# Altium schematic sheet IMU.SchDoc
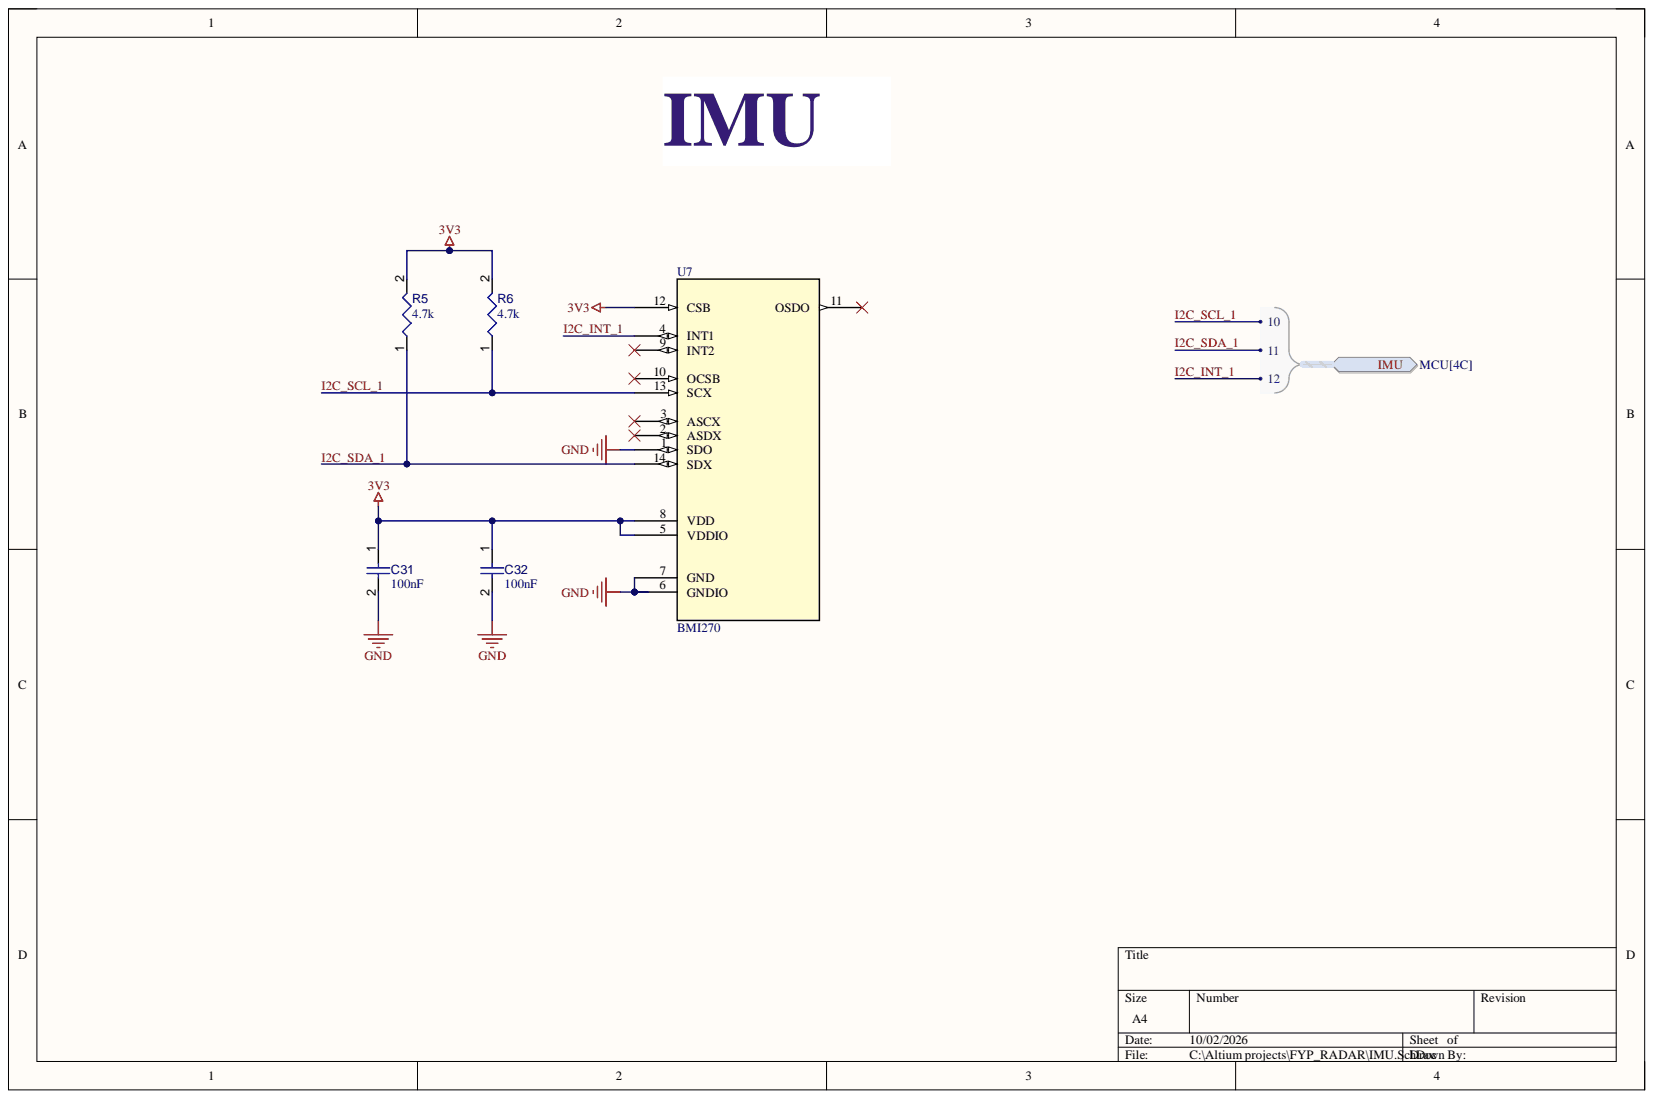
[diagram p0: IMU.SchDoc]

## Parts
C31  value 100nF  [CAPC1608X90X40NL10T20]  (hidden comment: Capacitor 100 nF +/-10% 50 V 0603)
C32  value 100nF  [CAPC1608X90X40NL10T20]  (hidden comment: Capacitor 100 nF +/-10% 50 V 0603)
R5  value 4.7k  [RESC1608X55X25ML10T15]  (hidden comment: Resistor 4k7 +/-1% 0603 100 mW)
R6  value 4.7k  [RESC1608X55X25ML10T15]  (hidden comment: Resistor 4k7 +/-1% 0603 100 mW)
U7  BMI270  [BMI270_BOS]

## Ports
IMU, IMU.10, IMU.11, IMU.12

## Nets
3V3: C31.1 C32.1 R5.2 R6.2 U7.5(VDDIO) U7.8(VDD) U7.12(CSB)
GND: C31.2 C32.2 U7.1(SDO) U7.6(GNDIO) U7.7(GND)
I2C_INT_1: U7.4(INT1) ; port IMU
I2C_SCL_1: R6.1 U7.13(SCX) ; port IMU
I2C_SDA_1: R5.1 U7.14(SDX) ; port IMU

Not connected: U7 2(ASDX) 3(ASCX) 9(INT2) 10(OCSB) 11(OSDO)

## Library data of the parts
C31 C32 = CMP-001-00017-6: Chip Capacitor, 100 nF, +/- 10%, 50 V, 0603 (1608 Metric); Capacitor Type: Ceramic; Voltage Rating: 50V; Case/Package: 0603; Component Kind: Standard; Max Operating Temperature: 125°C; Min Operating Temperature: -55°C; Mounting Technology: SM; Pins: 2; RoHS Compliant: True; Tolerance: 10%; Library Name: Kopithan Manoranjithan
R5 R6 = CMP-009-00031-5: Chip Resistor, 4.7 KOhm, +/- 1%, 0.1 W, -55 to 155 degC, 0603 (1608 Metric); Power: 100mW; Case/Package: 0603; Component Kind: Standard; Max Operating Temperature: 155°C; Min Operating Temperature: -55°C; Mounting Technology: SM; Pins: 2; RoHS Compliant: True; Tolerance: 1%; Library Name: Kopithan Manoranjithan
U7 = BMI270: Description; Manufacturer_Name: Bosch Sensortec; Component Kind: Standard; Library Name: BMI270.SchLib; Manufacturer_Part_Number: BMI270; RefDes: RefDes; Type: Type; Copyright: Copyright (C) 2025 Ultra Librarian. All rights reserved.
